# Altium schematic sheet uShield.SchDoc
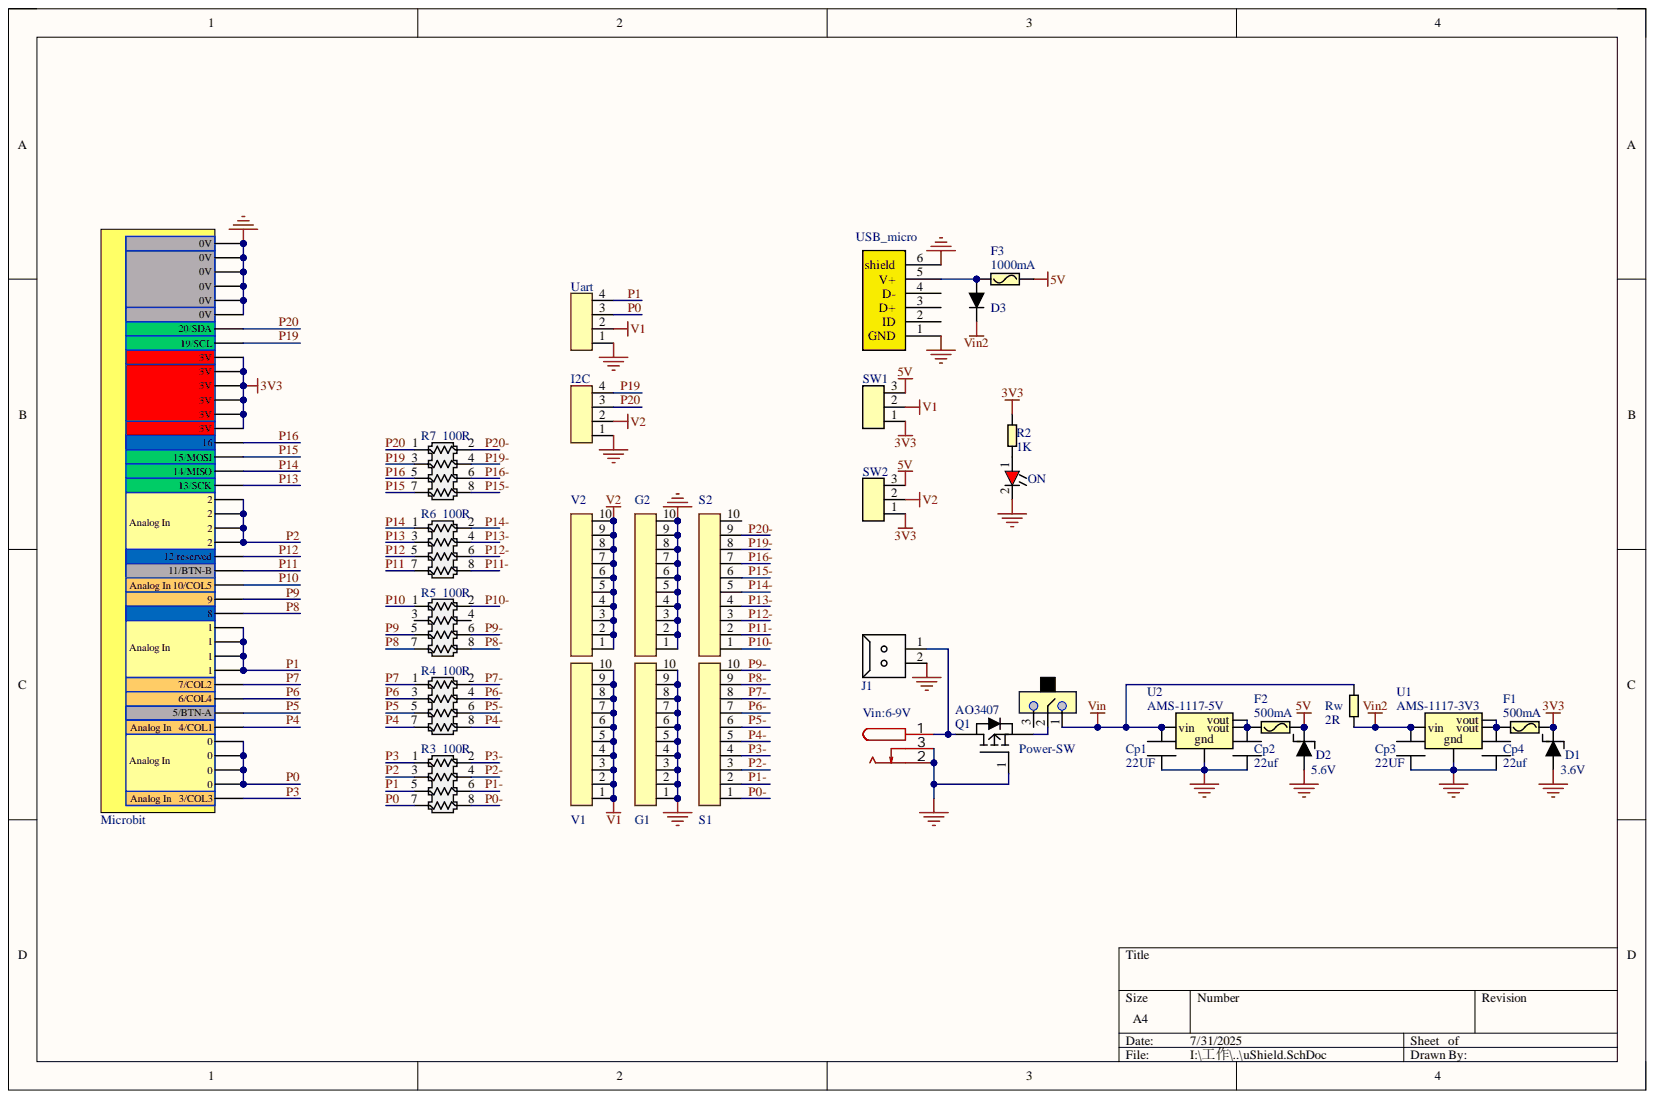
[diagram p0: uShield.SchDoc]

## Parts
Cp1  22UF  [0805]
Cp2  22uf  [0805]
Cp3  22UF  [0805]
Cp4  22uf  [0805]
D1  3.6V  [SOD-323]
D2  5.6V  [SOD-323]
D3  Diode  [SOD-123 - NEW]  (value not drawn)
F1  500mA  [0603]
F2  500mA  [0603]
F3  1000mA  [0603]
G1  Connector-10P  [1X10-2.54mm]  (value not drawn)
G2  Connector-10P  [1X10-2.54mm]  (value not drawn)
I2C  Connector-4P  [1X4-2.54MM]  (value not drawn)
J1  KF128 5.08mm 2P  [KF301 - 2P]  (value not drawn)
Microbit  Microbit-40p  [micro:bit slot]  (value not drawn)
ON  LED  [LED-0603]  (value not drawn)
Power-SW  SS-12F21G3  [SS12F44]  (value not drawn)
Q1  AO3407  [SOT-23_L]
R2  1K  [0603]
R3  100R  [排阻-4]
R4  100R  [排阻-4]
R5  100R  [排阻-4]
R6  100R  [排阻-4]
R7  100R  [排阻-4]
Rw  2R  [2010]
S1  Connector-10P  [1X10-2.54mm]  (value not drawn)
S2  Connector-10P  [1X10-2.54mm]  (value not drawn)
SW1  Connector-3P  [1x3-2.54mm - duplicate]  (value not drawn)
SW2  Connector-3P  [1x3-2.54mm - duplicate]  (value not drawn)
U1  AMS-1117-3V3  [SOT-223]
U2  AMS-1117-5V  [SOT-223]
Uart  Connector-4P  [1X4-2.54MM]  (value not drawn)
USB_micro  USB_micro  [micro_USB]
V1  Connector-10P  [1X10-2.54mm]  (value not drawn)
V2  Connector-10P  [1X10-2.54mm]  (value not drawn)
Vin:6-9V  DC-2.1/2.5  [DC-044]  (value not drawn)

## Nets
3V3: D1.2 F1.2 Microbit.9(3V) Microbit.10(3V) Microbit.11(3V) Microbit.12(3V) Microbit.13(3V) Microbit.14(3V) R2.1 SW1.1 SW2.1
5V: D2.2 F2.2 F3.2 SW1.3 SW2.3
GND: Cp1.1 Cp2.1 Cp3.1 Cp4.1 D1.1 D2.1 G1.1 G1.2 G1.3 G1.4 G1.5 G1.6 G1.7 G1.8 G1.9 G1.10 G2.1 G2.2 G2.3 G2.4 G2.5 G2.6 G2.7 G2.8 G2.9 G2.10 I2C.1 J1.2 Microbit.1(0V) Microbit.2(0V) Microbit.3(0V) Microbit.4(0V) Microbit.5(0V) Microbit.6(0V) ON.2 Q1.1 U1.1 U2.1 Uart.1 USB_micro.1(GND) USB_micro.6(shield) Vin:6-9V.2 Vin:6-9V.3
V1: SW1.2 Uart.2 V1.1 V1.2 V1.3 V1.4 V1.5 V1.6 V1.7 V1.8 V1.9 V1.10
V2: I2C.2 SW2.2 V2.1 V2.2 V2.3 V2.4 V2.5 V2.6 V2.7 V2.8 V2.9 V2.10
Vin: Cp1.2 Power-SW.1 Rw.2 U2.3(vin)
Vin2: Cp3.2 D3.2 Rw.1 U1.3(vin)
P0: Microbit.36 Microbit.37 Microbit.38 Microbit.39 R3.7 Uart.3
P0-: R3.8 S1.1
P1: Microbit.28 Microbit.29 Microbit.30 Microbit.31 R3.5 Uart.4
P1-: R3.6 S1.2
P2: Microbit.19 Microbit.20 Microbit.21 Microbit.22 R3.3
P2-: R3.4 S1.3
P3: Microbit.40(3/COL3) R3.1
P3-: R3.2 S1.4
P4: Microbit.35(4/COL1) R4.7
P4-: R4.8 S1.5
P5: Microbit.34(5/BTN-A) R4.5
P5-: R4.6 S1.6
P6: Microbit.33(6/COL4) R4.3
P6-: R4.4 S1.7
P7: Microbit.32(7/COL2) R4.1
P7-: R4.2 S1.8
P8: Microbit.27 R5.7
P8-: R5.8 S1.9
P9: Microbit.26 R5.5
P9-: R5.6 S1.10
P10: Microbit.25(Analog_In_10/COL5) R5.1
P10-: R5.2 S2.1
P11: Microbit.24(11/BTN-B) R6.7
P11-: R6.8 S2.2
P12: Microbit.23(12/reserved) R6.5
P12-: R6.6 S2.3
P13: Microbit.18(13/SCK) R6.3
P13-: R6.4 S2.4
P14: Microbit.17(14/MISO) R6.1
P14-: R6.2 S2.5
P15: Microbit.16(15/MOSI) R7.7
P15-: R7.8 S2.6
P16: Microbit.15 R7.5
P16-: R7.6 S2.7
P19: I2C.4 Microbit.8(19/SCL) R7.3
P19-: R7.4 S2.8
P20: I2C.3 Microbit.7(20/SDA) R7.1
P20-: R7.2 S2.9
NetCp2_2: Cp2.2 F2.1 U2.2(vout) U2.4(vout)
NetCp4_2: Cp4.2 F1.1 U1.2(vout) U1.4(vout)
NetD3_1: D3.1 F3.1 USB_micro.5(V+)
NetJ1_1: J1.1 Q1.3 Vin:6-9V.1
NetON_1: ON.1 R2.2
NetPower-SW_2: Power-SW.2 Q1.2

Not connected: Power-SW 3; R5 3 4; S2 10; USB_micro 2(ID) 3(D+) 4(D-)

## Library data of the parts
Cp1 Cp3 = CAP-1210: Component Kind: Standard; Library Name: Altium_Zizhi_Lib.SchLib
Cp2 Cp4 = CAP-0805: Component Kind: Standard; Library Name: Altium_Zizhi_Lib.SchLib
D1 D2 = Diode-W: Component Kind: Standard; Library Name: Altium_Zizhi_Lib.SchLib
F1 F2 = FUSE: 耐压：12V  最大电流：40A  保持电流：500mA  跳闸电流：1A; Component Kind: Standard; Library Name: Altium_Zizhi_Lib.SchLib
F3 = FUSE: 耐压：12V  最大电流：40A  保持电流：1000mA  跳闸电流：2A; Component Kind: Standard; Library Name: Altium_Zizhi_Lib.SchLib
Power-SW = switch_3P: Component Kind: Standard; Library Name: Altium_Zizhi_Lib.SchLib
R2 Rw = Resistor: Component Kind: Standard; Library Name: Altium_Zizhi_Lib.SchLib
R3-R7 = Resistor array: Component Kind: Standard; Library Name: Altium_Zizhi_Lib.SchLib
U1 U2 = AMS-1117: Component Kind: Standard; Library Name: Altium_Zizhi_Lib.SchLib
D3 = Diode: Component Kind: Standard; Library Name: Altium_Zizhi_Lib.SchLib
G1 G2 S1 S2 V1 V2 = Connector-10P: Component Kind: Standard; Library Name: Altium_Zizhi_Lib.SchLib
I2C Uart = Connector-4P: Component Kind: Standard; Library Name: Altium_Zizhi_Lib.SchLib
J1 = KF128 5.08mm 2P: Component Kind: Standard; Library Name: Altium_Zizhi_Lib.SchLib
Microbit = Microbit-40p: Component Kind: Standard; Library Name: Altium_Zizhi_Lib.SchLib
ON = LED: Component Kind: Standard; Library Name: Altium_Zizhi_Lib.SchLib
Q1 = AO3407: Component Kind: Standard; Library Name: Altium_Zizhi_Lib.SchLib
SW1 SW2 = Connector-3P: Component Kind: Standard; Library Name: Altium_Zizhi_Lib.SchLib
USB_micro = USB_micro: Component Kind: Standard; Library Name: Altium_Zizhi_Lib.SchLib
Vin:6-9V = DC-2.1/2.5: Component Kind: Standard; Library Name: Altium_Zizhi_Lib.SchLib
